# Altium schematic sheet BatterySentinel-N2K-Orion-R5-Power.SchDoc(BatterySentinel-N2K-Orion-R5-Power)
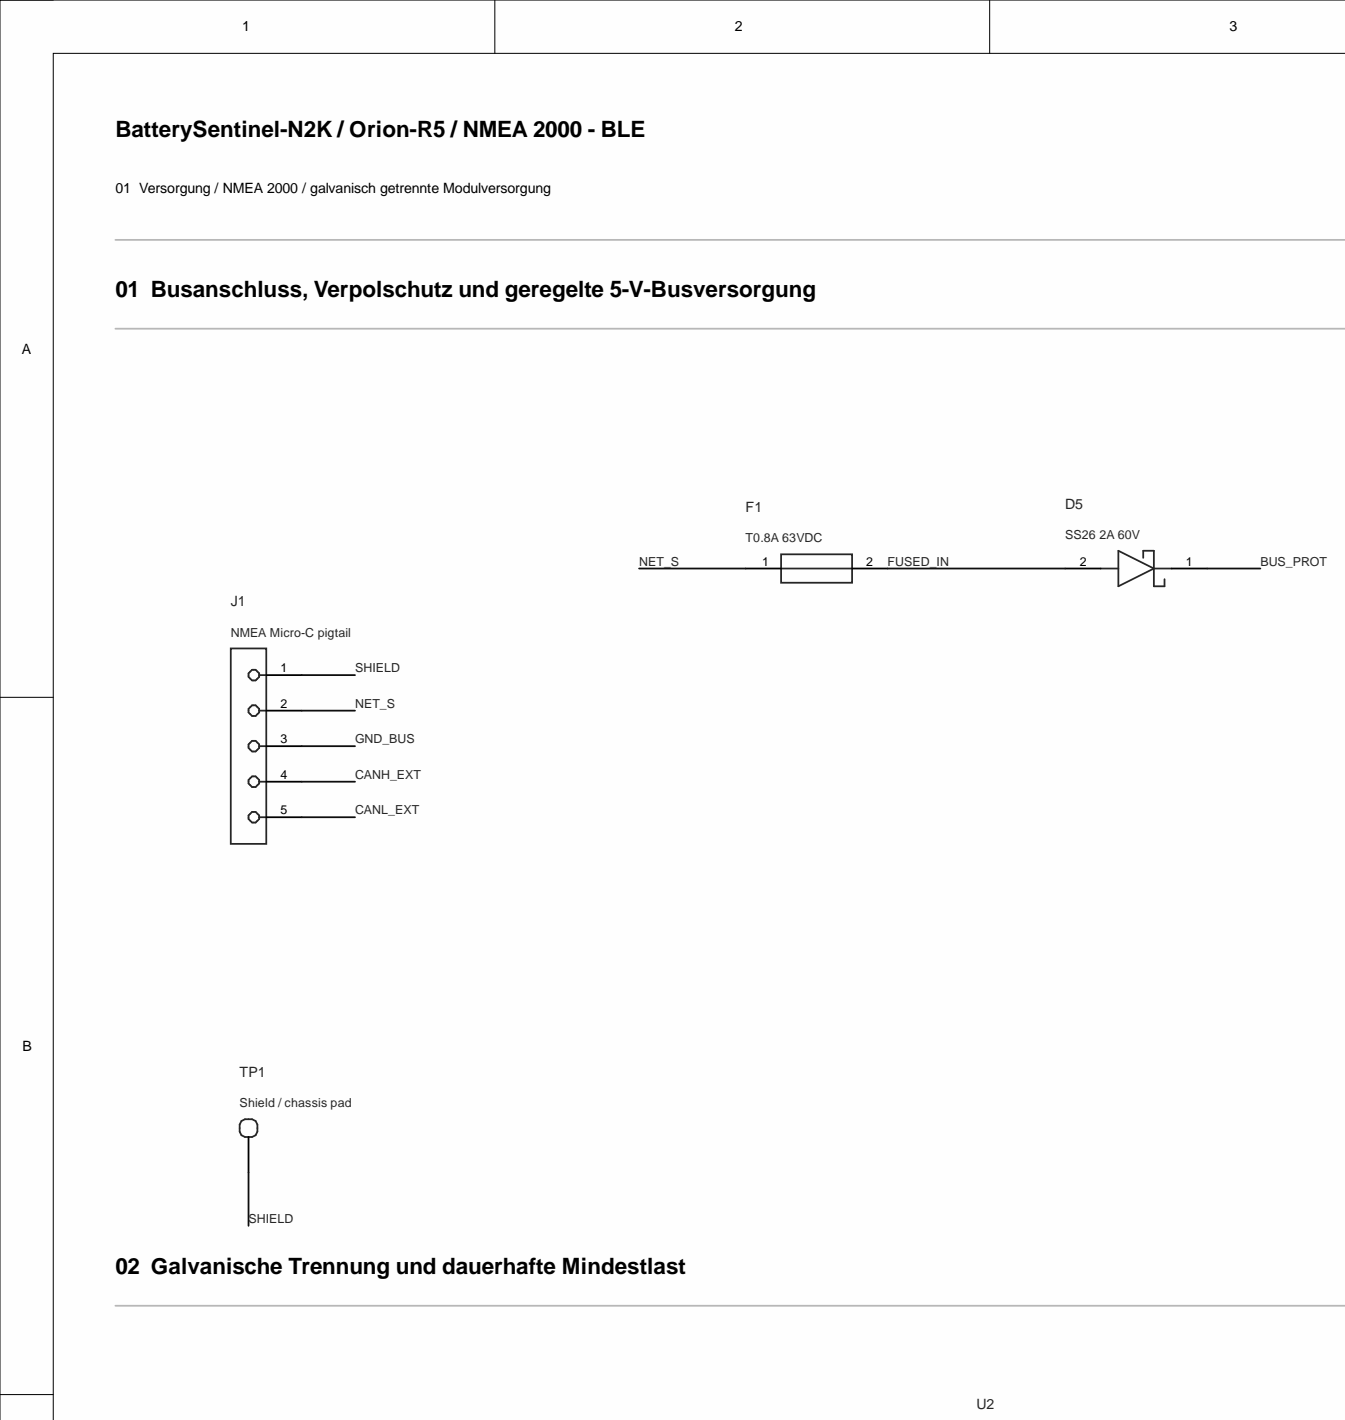
[diagram p0: BatterySentinel-N2K-Orion-R5-Power.SchDoc, top left]
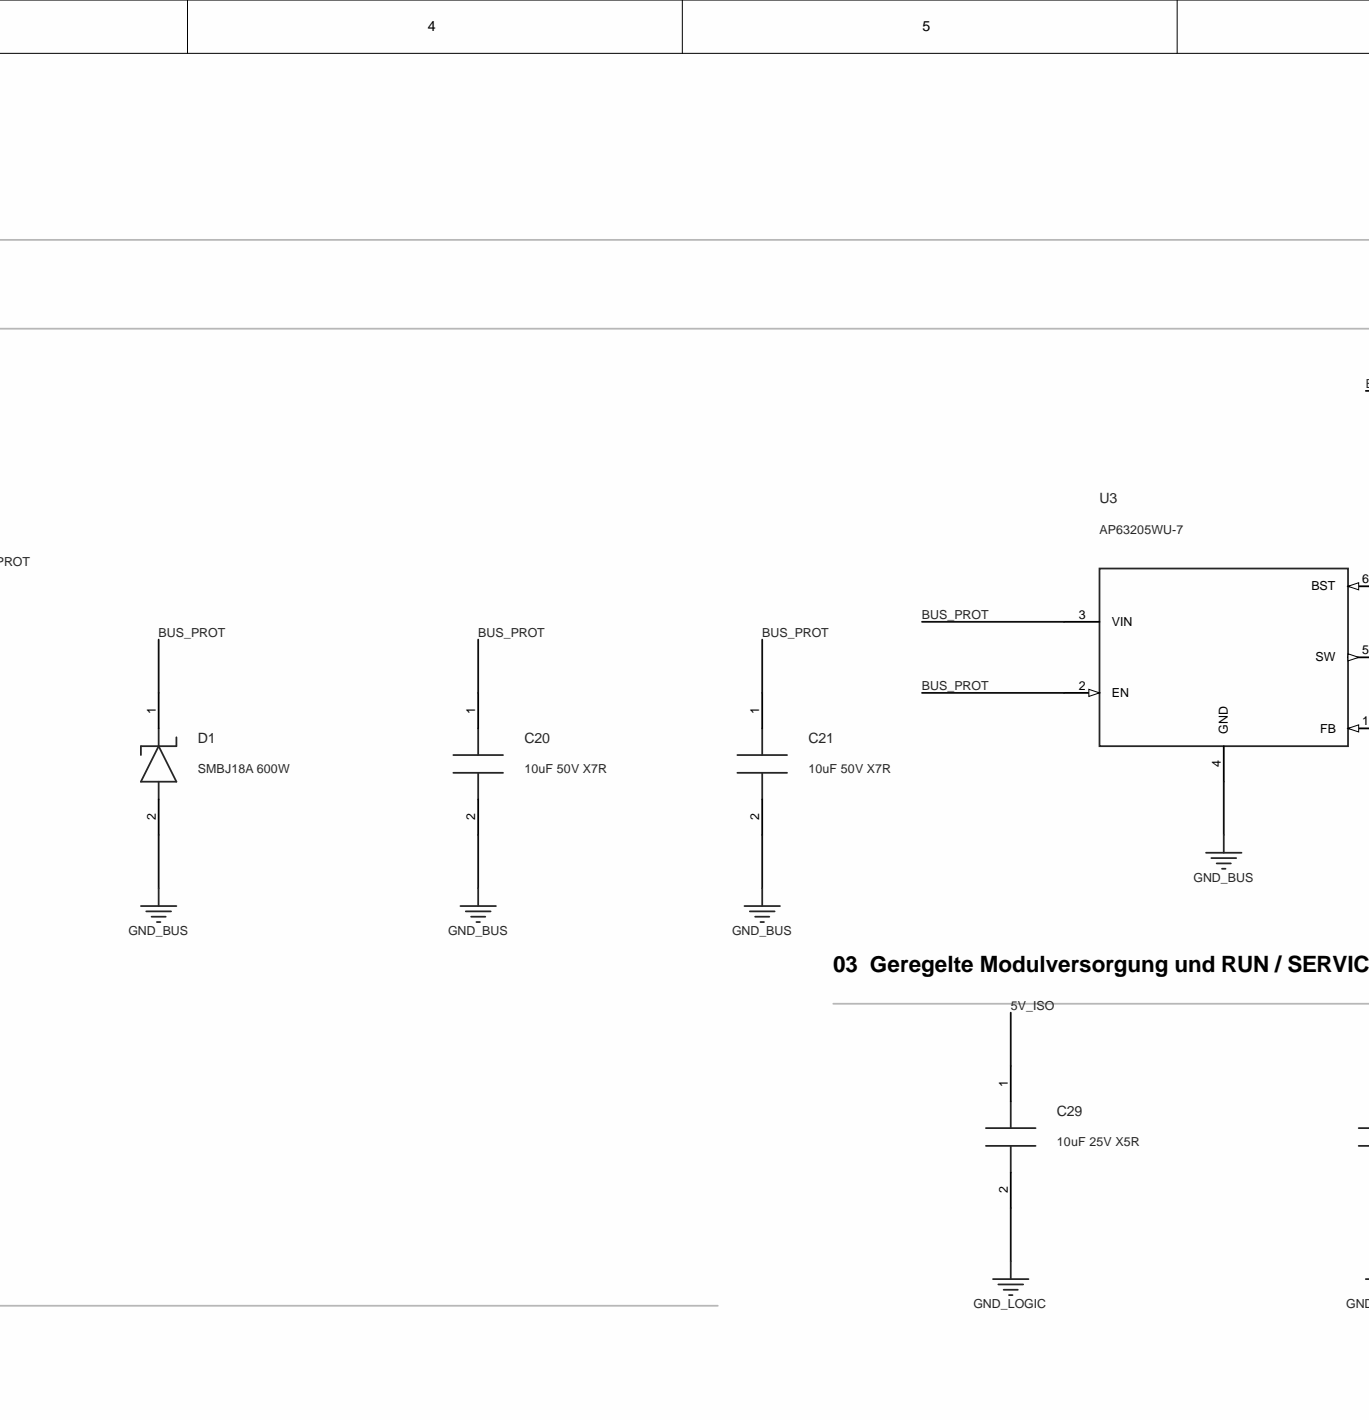
[diagram p1: BatterySentinel-N2K-Orion-R5-Power.SchDoc, top centre]
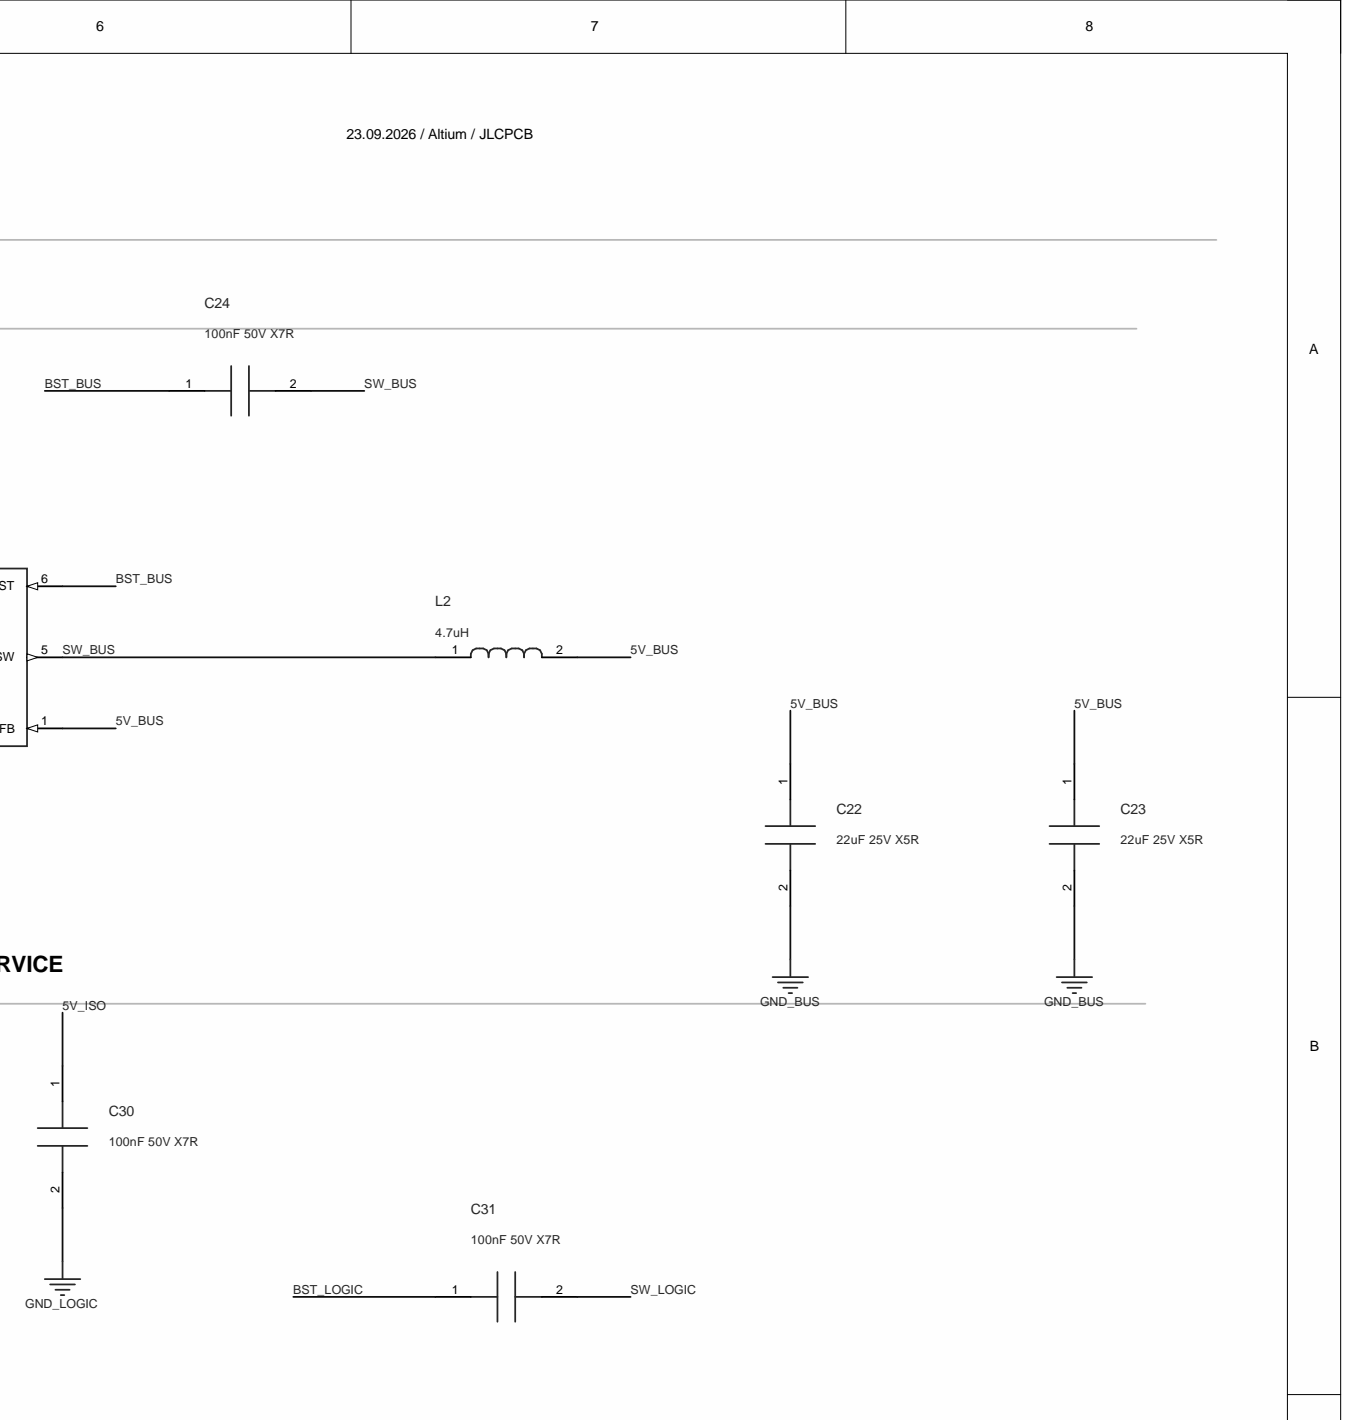
[diagram p2: BatterySentinel-N2K-Orion-R5-Power.SchDoc, top right]
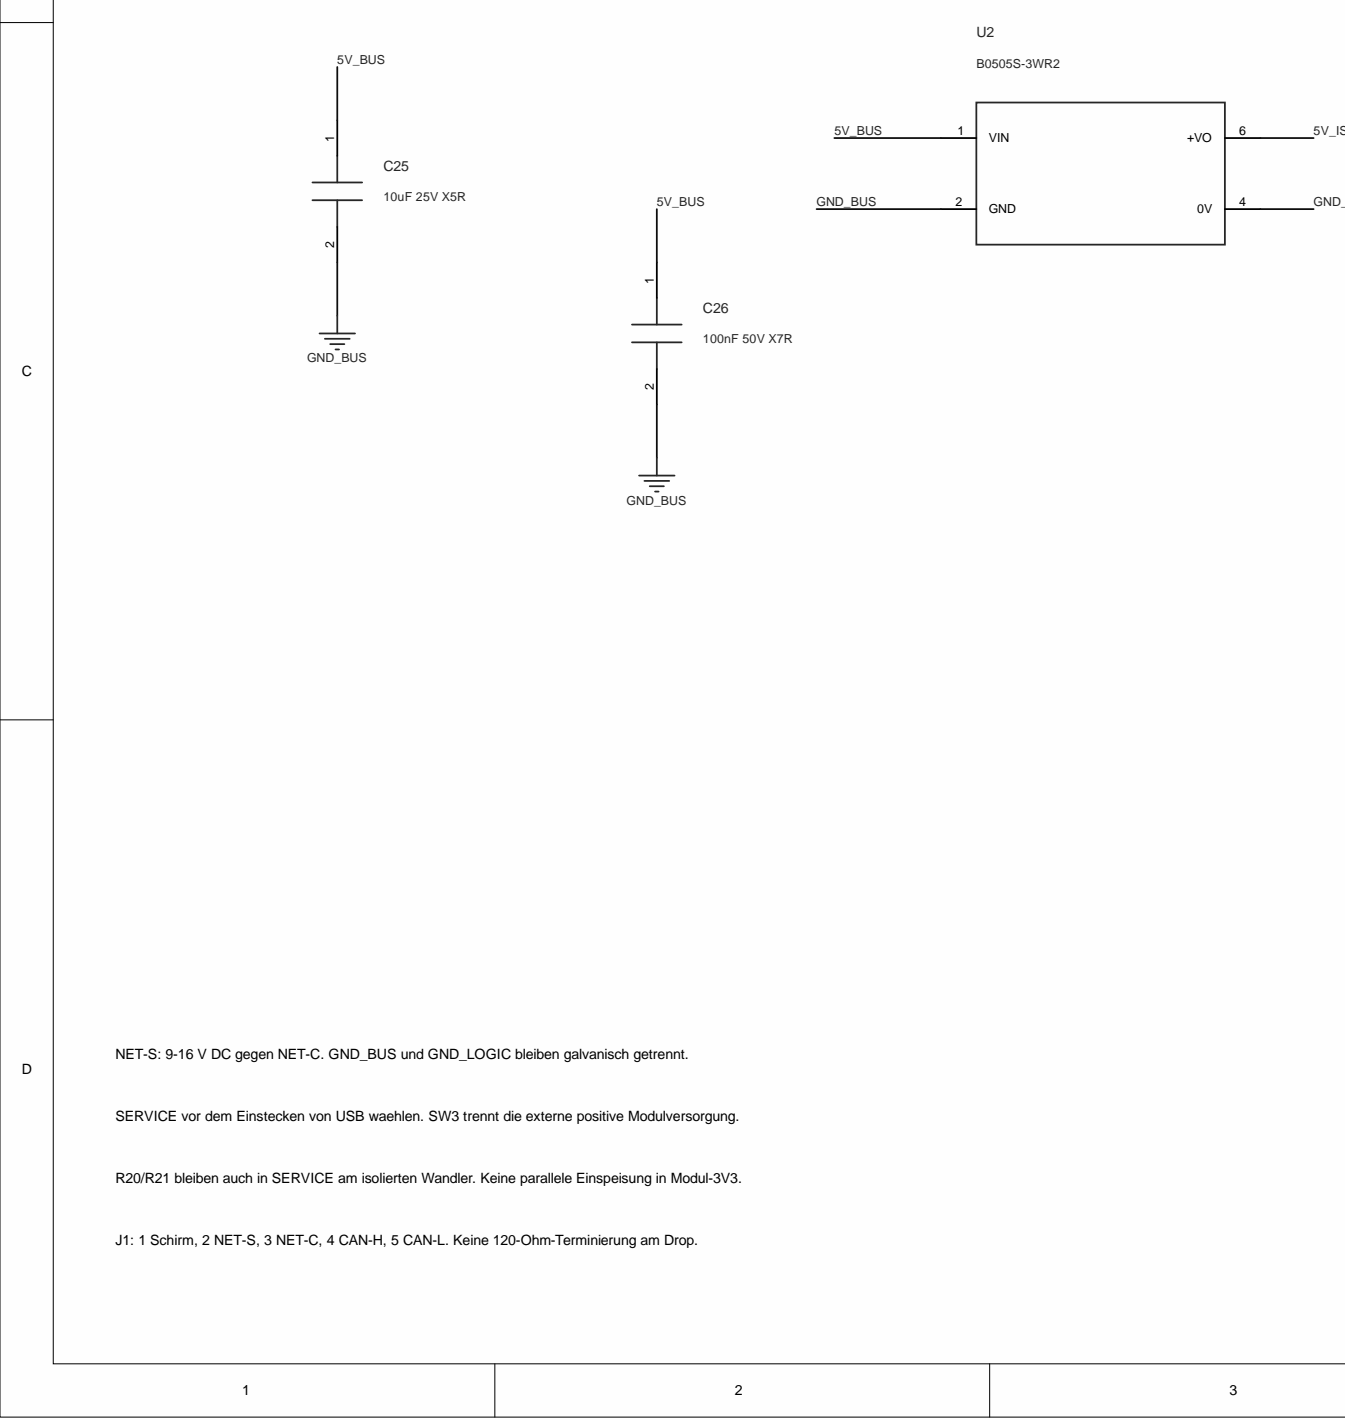
[diagram p3: BatterySentinel-N2K-Orion-R5-Power.SchDoc, bottom left]
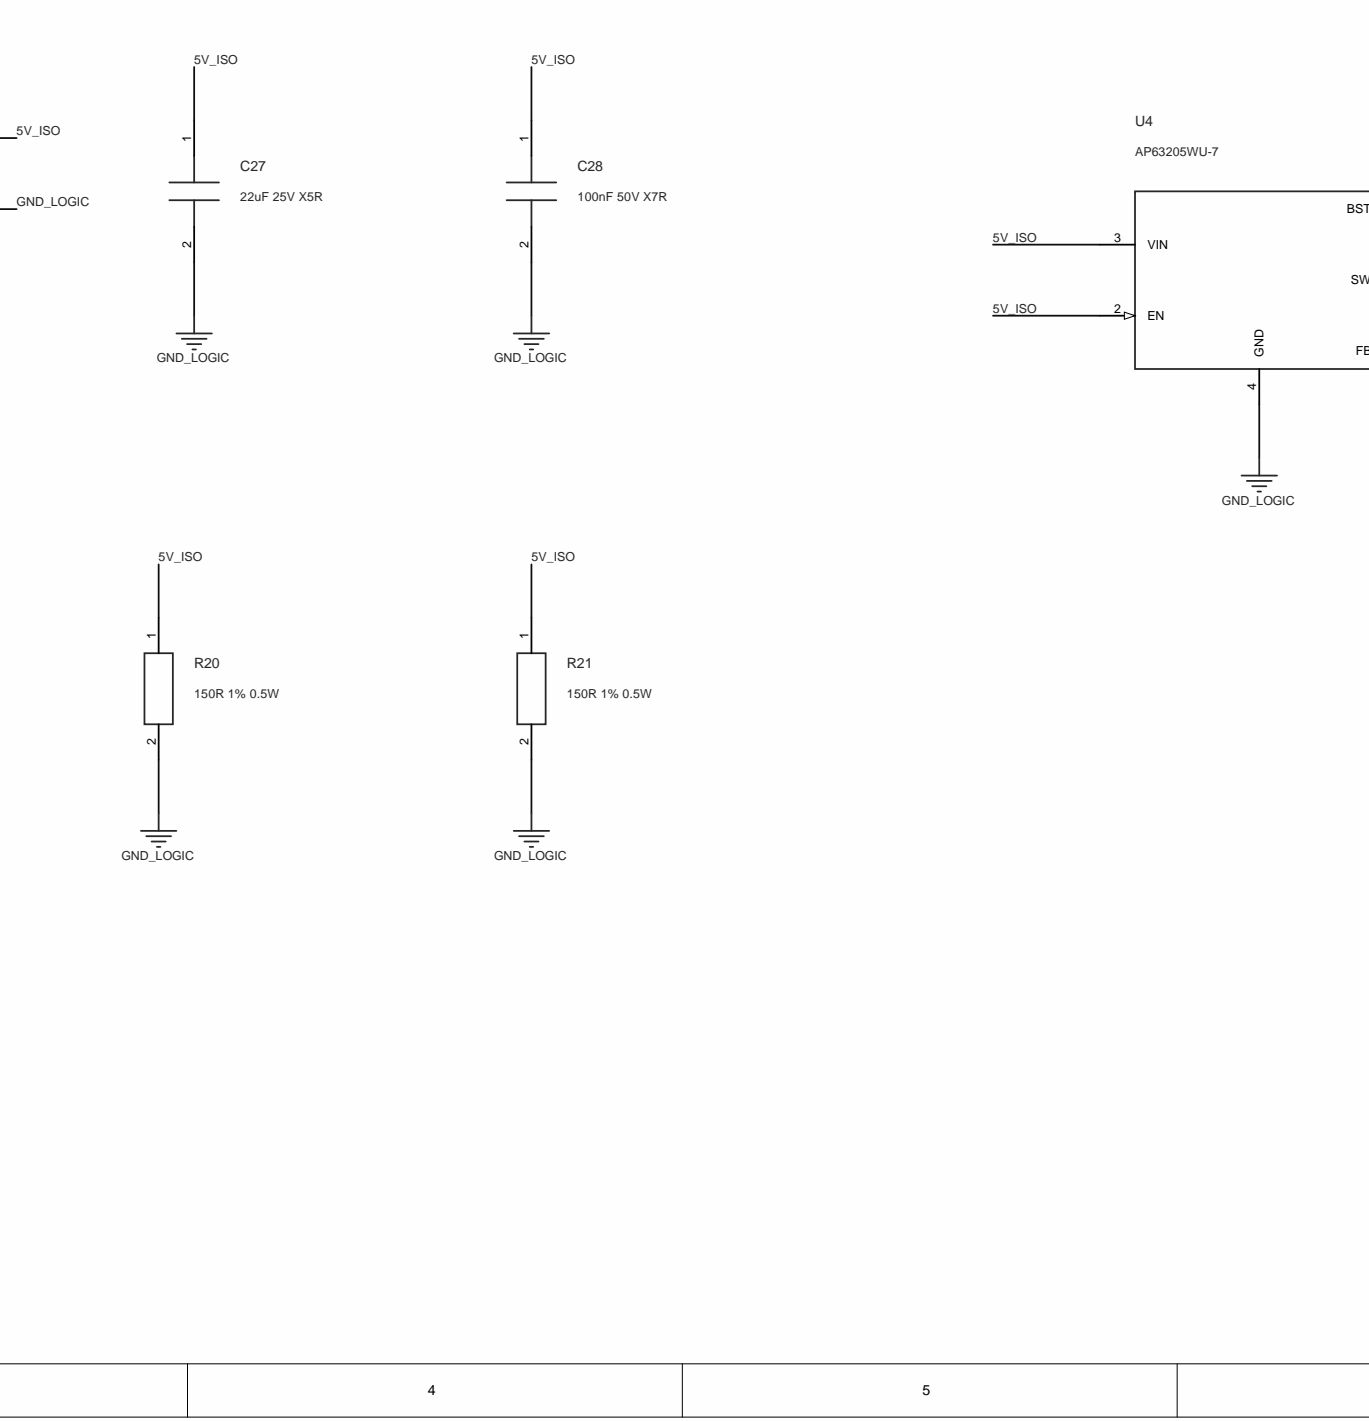
[diagram p4: BatterySentinel-N2K-Orion-R5-Power.SchDoc, bottom centre]
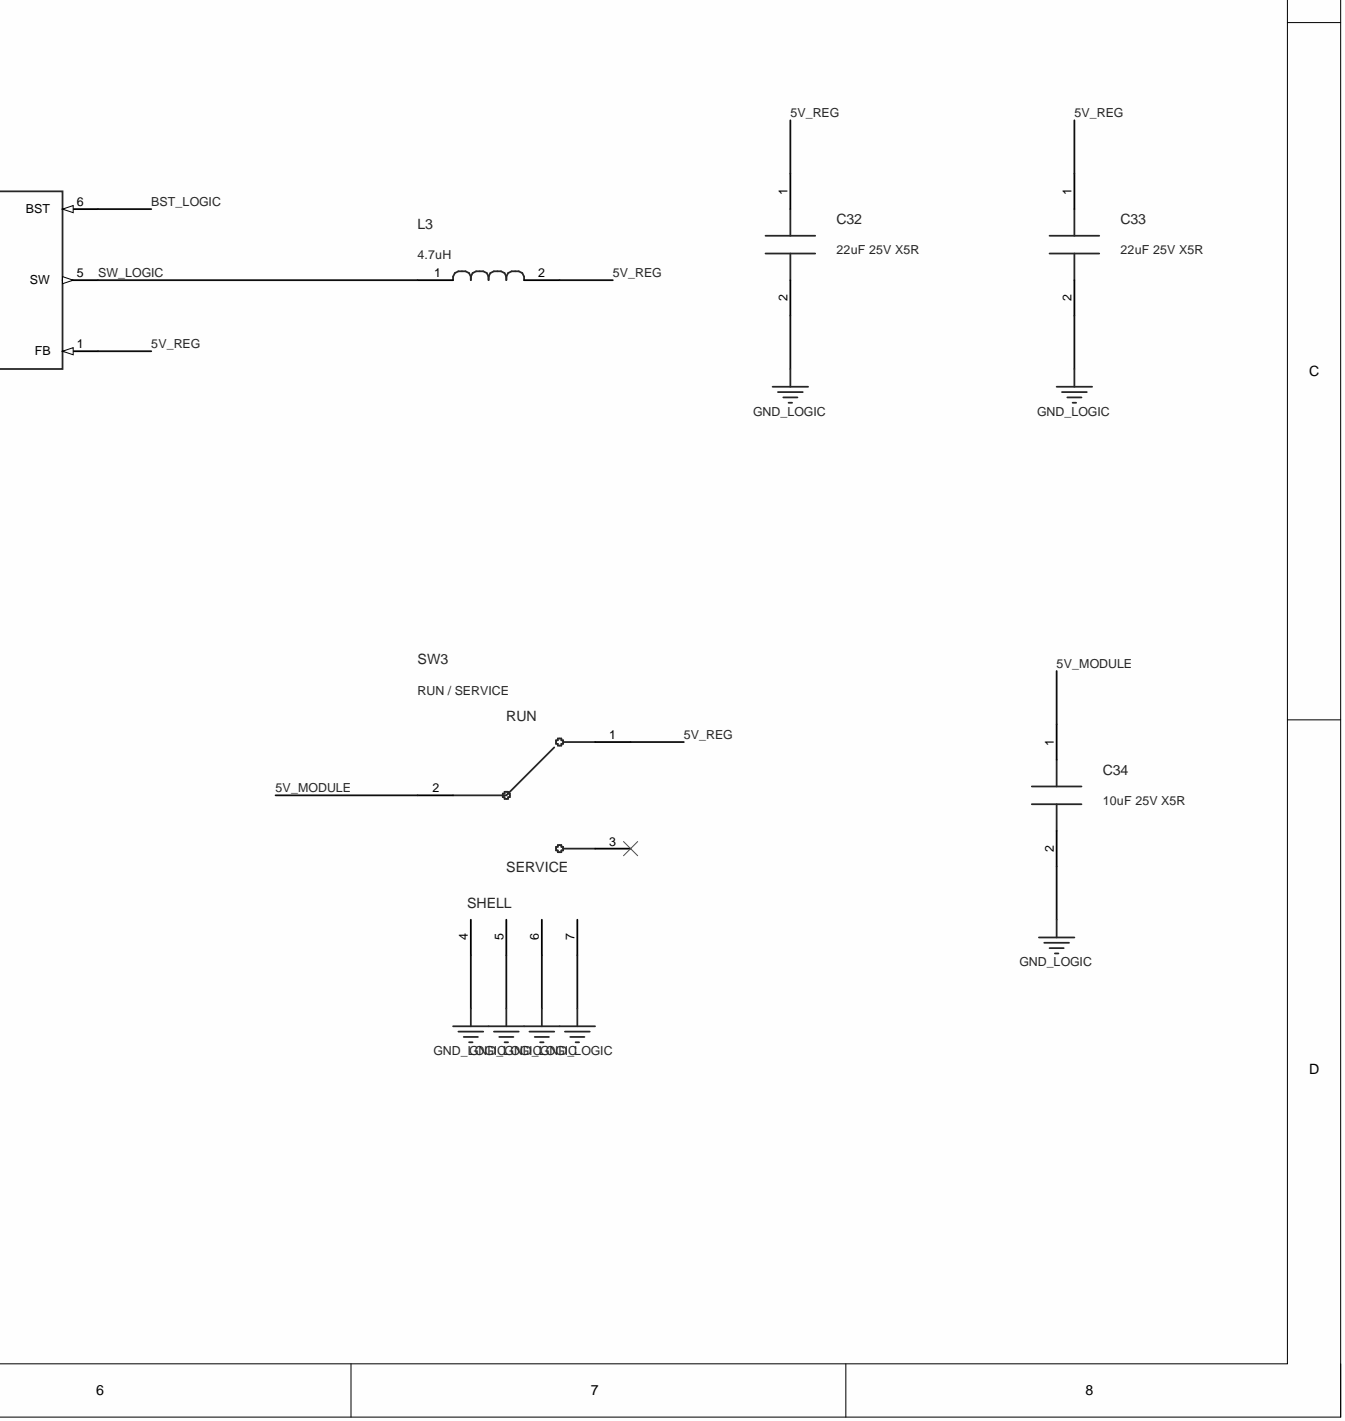
[diagram p5: BatterySentinel-N2K-Orion-R5-Power.SchDoc, bottom right]

## Parts
C20  10uF 50V X7R  [C1210]
C21  10uF 50V X7R  [C1210]
C22  22uF 25V X5R  [C1206]
C23  22uF 25V X5R  [C1206]
C24  100nF 50V X7R  [C0603]
C25  10uF 25V X5R  [C0805]
C26  100nF 50V X7R  [C0603]
C27  22uF 25V X5R  [C1206]
C28  100nF 50V X7R  [C0603]
C29  10uF 25V X5R  [C0805]
C30  100nF 50V X7R  [C0603]
C31  100nF 50V X7R  [C0603]
C32  22uF 25V X5R  [C1206]
C33  22uF 25V X5R  [C1206]
C34  10uF 25V X5R  [C0805]
D1  SMBJ18A 600W  Manufacturer Part Number: SMBJ18A  [SMB]
D5  SS26 2A 60V  Manufacturer Part Number: SS26  [SMA]
F1  T0.8A 63VDC  [BOURNS_SF1206S]
J1  NMEA Micro-C pigtail  [PIGTAIL_5_P3_D1P3]
L2  4.7uH  [SUNLORD_SWPA4030]
L3  4.7uH  [SUNLORD_SWPA4030]
R20  150R 1% 0.5W  [R1206]
R21  150R 1% 0.5W  [R1206]
SW3  RUN / SERVICE  [GSWITCH_MK12C02_G020]
TP1  Shield / chassis pad  [TESTPOINT_2MM]
U2  B0505S-3WR2  [EVISUN_B0505S_3WR2]
U3  AP63205WU-7  [TSOT26_DIODES]
U4  AP63205WU-7  [TSOT26_DIODES]

## Nets
5V_BUS: C22.1 C23.1 C25.1 C26.1 L2.2 U2.1(VIN) U3.1(FB)
5V_ISO: C27.1 C28.1 C29.1 C30.1 R20.1 R21.1 U2.6(+VO) U4.2(EN) U4.3(VIN)
5V_MODULE: C34.1 SW3.2
5V_REG: C32.1 C33.1 L3.2 SW3.1 U4.1(FB)
BST_BUS: C24.1 U3.6(BST)
BST_LOGIC: C31.1 U4.6(BST)
BUS_PROT: C20.1 C21.1 D1.1 D5.1 U3.2(EN) U3.3(VIN)
CANH_EXT: J1.4
CANL_EXT: J1.5
FUSED_IN: D5.2 F1.2
GND_BUS: C20.2 C21.2 C22.2 C23.2 C25.2 C26.2 D1.2 J1.3 U2.2(GND) U3.4(GND)
GND_LOGIC: C27.2 C28.2 C29.2 C30.2 C32.2 C33.2 C34.2 R20.2 R21.2 SW3.4 SW3.5 SW3.6 SW3.7 U2.4(0V) U4.4(GND)
NET_S: F1.1 J1.2
SHIELD: J1.1 TP1.1
SW_BUS: C24.2 L2.1 U3.5(SW)
SW_LOGIC: C31.2 L3.1 U4.5(SW)

Not connected: SW3 3

## Library data of the parts
C20 = R5_C20_10uF: Manufacturer: Samsung Electro-Mechanics; Manufacturer Part Number: CL32B106KBJNNNE; ReviewStatus: R5 placement with enclosure interface; Component Kind: Standard; Datasheet: https://datasheet.lcsc.com/lcsc/1912112237_Samsung-Electro-Mechanics-CL32B106KBJNNNE_C138687.pdf; Description: 10uF 50V X7R; Footprint Status: R5 placement with enclosure interface; MPN: CL32B106KBJNNNE; Supplier 1: LCSC; Supplier Part Number 1: C138687; Library Name: Orion-Bridge.SchLib
C21 = R5_C21_10uF: Manufacturer: Samsung Electro-Mechanics; Manufacturer Part Number: CL32B106KBJNNNE; ReviewStatus: R5 placement with enclosure interface; Component Kind: Standard; Datasheet: https://datasheet.lcsc.com/lcsc/1912112237_Samsung-Electro-Mechanics-CL32B106KBJNNNE_C138687.pdf; Description: 10uF 50V X7R; Footprint Status: R5 placement with enclosure interface; MPN: CL32B106KBJNNNE; Supplier 1: LCSC; Supplier Part Number 1: C138687; Library Name: Orion-Bridge.SchLib
C22 = R5_C22_22uF: Manufacturer: Samsung Electro-Mechanics; Manufacturer Part Number: CL31A226KAHNNNE; ReviewStatus: R5 placement with enclosure interface; Component Kind: Standard; Datasheet: https://www.lcsc.com/datasheet/lcsc_datasheet_2304140030_Samsung-Electro-Mechanics-CL31A226KAHNNNE_C12891.pdf; Description: 22uF 25V X5R; Footprint Status: R5 placement with enclosure interface; MPN: CL31A226KAHNNNE; Supplier 1: LCSC; Supplier Part Number 1: C12891; Library Name: Orion-Bridge.SchLib
C23 = R5_C23_22uF: Manufacturer: Samsung Electro-Mechanics; Manufacturer Part Number: CL31A226KAHNNNE; ReviewStatus: R5 placement with enclosure interface; Component Kind: Standard; Datasheet: https://www.lcsc.com/datasheet/lcsc_datasheet_2304140030_Samsung-Electro-Mechanics-CL31A226KAHNNNE_C12891.pdf; Description: 22uF 25V X5R; Footprint Status: R5 placement with enclosure interface; MPN: CL31A226KAHNNNE; Supplier 1: LCSC; Supplier Part Number 1: C12891; Library Name: Orion-Bridge.SchLib
C24 = R5_C24_100nF: Manufacturer: YAGEO; Manufacturer Part Number: CC0603KRX7R9BB104; ReviewStatus: R5 placement with enclosure interface; Component Kind: Standard; Datasheet: https://www.lcsc.com/datasheet/lcsc_datasheet_2211101700_YAGEO-CC0603KRX7R9BB104_C14663.pdf; Description: 100nF 50V X7R; Footprint Status: R5 placement with enclosure interface; MPN: CC0603KRX7R9BB104; Supplier 1: LCSC; Supplier Part Number 1: C14663; Library Name: Orion-Bridge.SchLib
C25 = R5_C25_10uF: Manufacturer: Samsung Electro-Mechanics; Manufacturer Part Number: CL21A106KAYNNNE; ReviewStatus: R5 placement with enclosure interface; Component Kind: Standard; Datasheet: https://www.lcsc.com/datasheet/lcsc_datasheet_2304140030_Samsung-Electro-Mechanics-CL21A106KAYNNNE_C15850.pdf; Description: 10uF 25V X5R; Footprint Status: R5 placement with enclosure interface; MPN: CL21A106KAYNNNE; Supplier 1: LCSC; Supplier Part Number 1: C15850; Library Name: Orion-Bridge.SchLib
C26 = R5_C26_100nF: Manufacturer: YAGEO; Manufacturer Part Number: CC0603KRX7R9BB104; ReviewStatus: R5 placement with enclosure interface; Component Kind: Standard; Datasheet: https://www.lcsc.com/datasheet/lcsc_datasheet_2211101700_YAGEO-CC0603KRX7R9BB104_C14663.pdf; Description: 100nF 50V X7R; Footprint Status: R5 placement with enclosure interface; MPN: CC0603KRX7R9BB104; Supplier 1: LCSC; Supplier Part Number 1: C14663; Library Name: Orion-Bridge.SchLib
C27 = R5_C27_22uF: Manufacturer: Samsung Electro-Mechanics; Manufacturer Part Number: CL31A226KAHNNNE; ReviewStatus: R5 placement with enclosure interface; Component Kind: Standard; Datasheet: https://www.lcsc.com/datasheet/lcsc_datasheet_2304140030_Samsung-Electro-Mechanics-CL31A226KAHNNNE_C12891.pdf; Description: 22uF 25V X5R; Footprint Status: R5 placement with enclosure interface; MPN: CL31A226KAHNNNE; Supplier 1: LCSC; Supplier Part Number 1: C12891; Library Name: Orion-Bridge.SchLib
C28 = R5_C28_100nF: Manufacturer: YAGEO; Manufacturer Part Number: CC0603KRX7R9BB104; ReviewStatus: R5 placement with enclosure interface; Component Kind: Standard; Datasheet: https://www.lcsc.com/datasheet/lcsc_datasheet_2211101700_YAGEO-CC0603KRX7R9BB104_C14663.pdf; Description: 100nF 50V X7R; Footprint Status: R5 placement with enclosure interface; MPN: CC0603KRX7R9BB104; Supplier 1: LCSC; Supplier Part Number 1: C14663; Library Name: Orion-Bridge.SchLib
C29 = R5_C29_10uF: Manufacturer: Samsung Electro-Mechanics; Manufacturer Part Number: CL21A106KAYNNNE; ReviewStatus: R5 placement with enclosure interface; Component Kind: Standard; Datasheet: https://www.lcsc.com/datasheet/lcsc_datasheet_2304140030_Samsung-Electro-Mechanics-CL21A106KAYNNNE_C15850.pdf; Description: 10uF 25V X5R; Footprint Status: R5 placement with enclosure interface; MPN: CL21A106KAYNNNE; Supplier 1: LCSC; Supplier Part Number 1: C15850; Library Name: Orion-Bridge.SchLib
C30 = R5_C30_100nF: Manufacturer: YAGEO; Manufacturer Part Number: CC0603KRX7R9BB104; ReviewStatus: R5 placement with enclosure interface; Component Kind: Standard; Datasheet: https://www.lcsc.com/datasheet/lcsc_datasheet_2211101700_YAGEO-CC0603KRX7R9BB104_C14663.pdf; Description: 100nF 50V X7R; Footprint Status: R5 placement with enclosure interface; MPN: CC0603KRX7R9BB104; Supplier 1: LCSC; Supplier Part Number 1: C14663; Library Name: Orion-Bridge.SchLib
C31 = R5_C31_100nF: Manufacturer: YAGEO; Manufacturer Part Number: CC0603KRX7R9BB104; ReviewStatus: R5 placement with enclosure interface; Component Kind: Standard; Datasheet: https://www.lcsc.com/datasheet/lcsc_datasheet_2211101700_YAGEO-CC0603KRX7R9BB104_C14663.pdf; Description: 100nF 50V X7R; Footprint Status: R5 placement with enclosure interface; MPN: CC0603KRX7R9BB104; Supplier 1: LCSC; Supplier Part Number 1: C14663; Library Name: Orion-Bridge.SchLib
C32 = R5_C32_22uF: Manufacturer: Samsung Electro-Mechanics; Manufacturer Part Number: CL31A226KAHNNNE; ReviewStatus: R5 placement with enclosure interface; Component Kind: Standard; Datasheet: https://www.lcsc.com/datasheet/lcsc_datasheet_2304140030_Samsung-Electro-Mechanics-CL31A226KAHNNNE_C12891.pdf; Description: 22uF 25V X5R; Footprint Status: R5 placement with enclosure interface; MPN: CL31A226KAHNNNE; Supplier 1: LCSC; Supplier Part Number 1: C12891; Library Name: Orion-Bridge.SchLib
C33 = R5_C33_22uF: Manufacturer: Samsung Electro-Mechanics; Manufacturer Part Number: CL31A226KAHNNNE; ReviewStatus: R5 placement with enclosure interface; Component Kind: Standard; Datasheet: https://www.lcsc.com/datasheet/lcsc_datasheet_2304140030_Samsung-Electro-Mechanics-CL31A226KAHNNNE_C12891.pdf; Description: 22uF 25V X5R; Footprint Status: R5 placement with enclosure interface; MPN: CL31A226KAHNNNE; Supplier 1: LCSC; Supplier Part Number 1: C12891; Library Name: Orion-Bridge.SchLib
C34 = R5_C34_10uF: 10uF local module5V reservoir after RUN/SERVICE switch; Manufacturer: Samsung Electro-Mechanics; Manufacturer Part Number: CL21A106KAYNNNE; ReviewStatus: R5 placement with enclosure interface; Component Kind: Standard; Datasheet: https://www.lcsc.com/datasheet/lcsc_datasheet_2304140030_Samsung-Electro-Mechanics-CL21A106KAYNNNE_C15850.pdf; Footprint Status: R5 placement with enclosure interface; MPN: CL21A106KAYNNNE; Supplier 1: LCSC; Supplier Part Number 1: C15850; Library Name: Orion-Bridge.SchLib
D1 = R5_D1_SMBJ18A: Manufacturer: BORN; ReviewStatus: R5 placement with enclosure interface; Component Kind: Standard; Datasheet: https://www.lcsc.com/datasheet/lcsc_datasheet_2304140030_BORN-SMBJ18A_C152104.pdf; Description: SMBJ18A 600W; Footprint Status: R5 placement with enclosure interface; MPN: SMBJ18A; Supplier 1: LCSC; Supplier Part Number 1: C152104; Library Name: Orion-Bridge.SchLib
D5 = R5_D5_SS26: Manufacturer: MDD(Microdiode Semiconductor); ReviewStatus: R5 placement with enclosure interface; Component Kind: Standard; Datasheet: https://www.lcsc.com/datasheet/lcsc_datasheet_2407101107_MDD-Microdiode-Semiconductor-SS26_C14238.pdf; Description: SS26 2A 60V; Footprint Status: R5 placement with enclosure interface; MPN: SS26; Supplier 1: LCSC; Supplier Part Number 1: C14238; Library Name: Orion-Bridge.SchLib
F1 = R5_F1_T0.8A: 0.8 A slow-blow SMD ceramic fuse, 63 VDC, 50 A interrupt rating; Manufacturer: BOURNS; Manufacturer Part Number: SF-1206S080-2; ReviewStatus: R5 placement with enclosure interface; Component Kind: Standard; Datasheet: https://wmsc.lcsc.com/wmsc/upload/file/pdf/v2/lcsc/2304140030_BOURNS-SF-1206S080-2_C3032873.pdf; Footprint Status: R5 placement with enclosure interface; MPN: SF-1206S080-2; Supplier 1: LCSC; Supplier Part Number 1: C3032873; Library Name: Orion-Bridge.SchLib
J1 = R5_J1_NMEA: Five direct wire-solder pads for a separately selected Micro-C cable; this is a PCB interface, not an invented connector MPN or face view; ReviewStatus: R5 placement with enclosure interface; Component Kind: Standard; Footprint Status: R5 placement with enclosure interface; Library Name: Orion-Bridge.SchLib
L2 = R5_L2_4.7uH: Manufacturer: Sunlord; Manufacturer Part Number: SWPA4030S4R7MT; ReviewStatus: R5 placement with enclosure interface; Component Kind: Standard; Datasheet: https://www.lcsc.com/datasheet/lcsc_datasheet_2310251551_Sunlord-SWPA4030S4R7MT_C57269.pdf; Description: 4.7uH; Footprint Status: R5 placement with enclosure interface; MPN: SWPA4030S4R7MT; Supplier 1: LCSC; Supplier Part Number 1: C57269; Library Name: Orion-Bridge.SchLib
L3 = R5_L3_4.7uH: Manufacturer: Sunlord; Manufacturer Part Number: SWPA4030S4R7MT; ReviewStatus: R5 placement with enclosure interface; Component Kind: Standard; Datasheet: https://www.lcsc.com/datasheet/lcsc_datasheet_2310251551_Sunlord-SWPA4030S4R7MT_C57269.pdf; Description: 4.7uH; Footprint Status: R5 placement with enclosure interface; MPN: SWPA4030S4R7MT; Supplier 1: LCSC; Supplier Part Number 1: C57269; Library Name: Orion-Bridge.SchLib
R20 = R5_R20_150R: Manufacturer: SEI(Stackpole Elec); Manufacturer Part Number: RNCP1206FTD150R; ReviewStatus: R5 placement with enclosure interface; Component Kind: Standard; Datasheet: https://wmsc.lcsc.com/wmsc/upload/file/pdf/v2/lcsc/2404111532_SEI-Stackpole-Elec-RNCP1206FTD150R_C4258541.pdf; Description: 150R 1% 0.5W; Footprint Status: R5 placement with enclosure interface; MPN: RNCP1206FTD150R; Supplier 1: LCSC; Supplier Part Number 1: C4258541; Library Name: Orion-Bridge.SchLib
R21 = R5_R21_150R: Manufacturer: SEI(Stackpole Elec); Manufacturer Part Number: RNCP1206FTD150R; ReviewStatus: R5 placement with enclosure interface; Component Kind: Standard; Datasheet: https://wmsc.lcsc.com/wmsc/upload/file/pdf/v2/lcsc/2404111532_SEI-Stackpole-Elec-RNCP1206FTD150R_C4258541.pdf; Description: 150R 1% 0.5W; Footprint Status: R5 placement with enclosure interface; MPN: RNCP1206FTD150R; Supplier 1: LCSC; Supplier Part Number 1: C4258541; Library Name: Orion-Bridge.SchLib
SW3 = R5_SW3_RUN: Side-actuated SPDT service supply disconnect, 1A at6V, original SuperMini USB service; Manufacturer: G-Switch; Manufacturer Part Number: MK-12C02-G020; ReviewStatus: R5 placement with enclosure interface; Component Kind: Standard; Datasheet: https://static.chipdip.ru/lib/011/DOC043011829.pdf; Footprint Status: R5 placement with enclosure interface; MPN: MK-12C02-G020; Supplier 1: LCSC; Supplier Part Number 1: C963206; Library Name: Orion-Bridge.SchLib
TP1 = R5_TP1_Shield: Shield / chassis pad - generic specification; manufacturer/MPN not selected; ReviewStatus: R5 placement with enclosure interface; Component Kind: Standard; Footprint Status: R5 placement with enclosure interface; Library Name: Orion-Bridge.SchLib
U2 = R5_U2_B0505S_3WR2: Manufacturer: EVISUN; ReviewStatus: R5 placement with enclosure interface; Component Kind: Standard; Datasheet: https://wmsc.lcsc.com/wmsc/upload/file/pdf/v2/lcsc/2308311627_EVISUN-B0505S-3WR2_C7500927.pdf; Description: B0505S-3WR2; Footprint Status: R5 placement with enclosure interface; Manufacturer Part Number: B0505S-3WR2; MPN: B0505S-3WR2; Supplier 1: LCSC; Supplier Part Number 1: C7500927; Library Name: Orion-Bridge.SchLib
U3 = R5_U3_AP63205WU_7: Manufacturer: Diodes Incorporated; ReviewStatus: R5 placement with enclosure interface; Component Kind: Standard; Datasheet: https://www.lcsc.com/datasheet/lcsc_datasheet_2210280930_Diodes-Incorporated-AP63205WU-7_C2071056.pdf; Description: AP63205WU-7; Footprint Status: R5 placement with enclosure interface; Manufacturer Part Number: AP63205WU-7; MPN: AP63205WU-7; Supplier 1: LCSC; Supplier Part Number 1: C2071056; Library Name: Orion-Bridge.SchLib
U4 = R5_U4_AP63205WU_7: Isolated-side 5V buck / high-duty pass-through, feeding physical RUN/SERVICE disconnect; Manufacturer: Diodes Incorporated; ReviewStatus: R5 placement with enclosure interface; Component Kind: Standard; Datasheet: https://www.lcsc.com/datasheet/lcsc_datasheet_2210280930_Diodes-Incorporated-AP63205WU-7_C2071056.pdf; Footprint Status: R5 placement with enclosure interface; Manufacturer Part Number: AP63205WU-7; MPN: AP63205WU-7; Supplier 1: LCSC; Supplier Part Number 1: C2071056; Library Name: Orion-Bridge.SchLib
